# Altium schematic sheet LongHaul_Diff.SchDoc
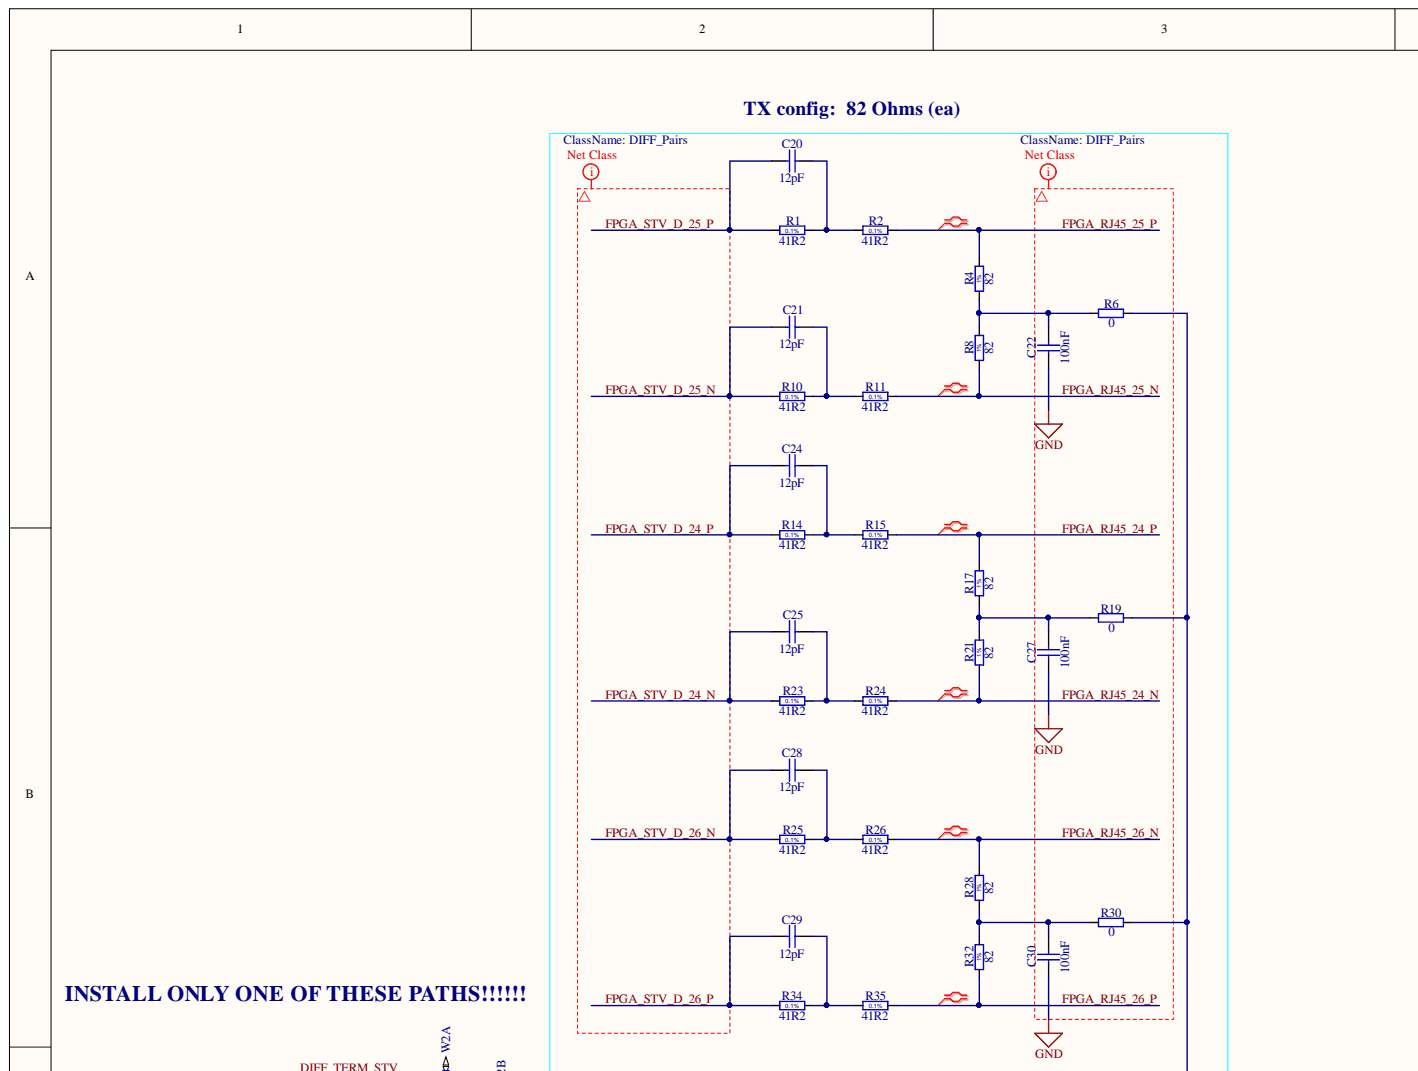
[diagram p0: LongHaul_Diff.SchDoc, top left]
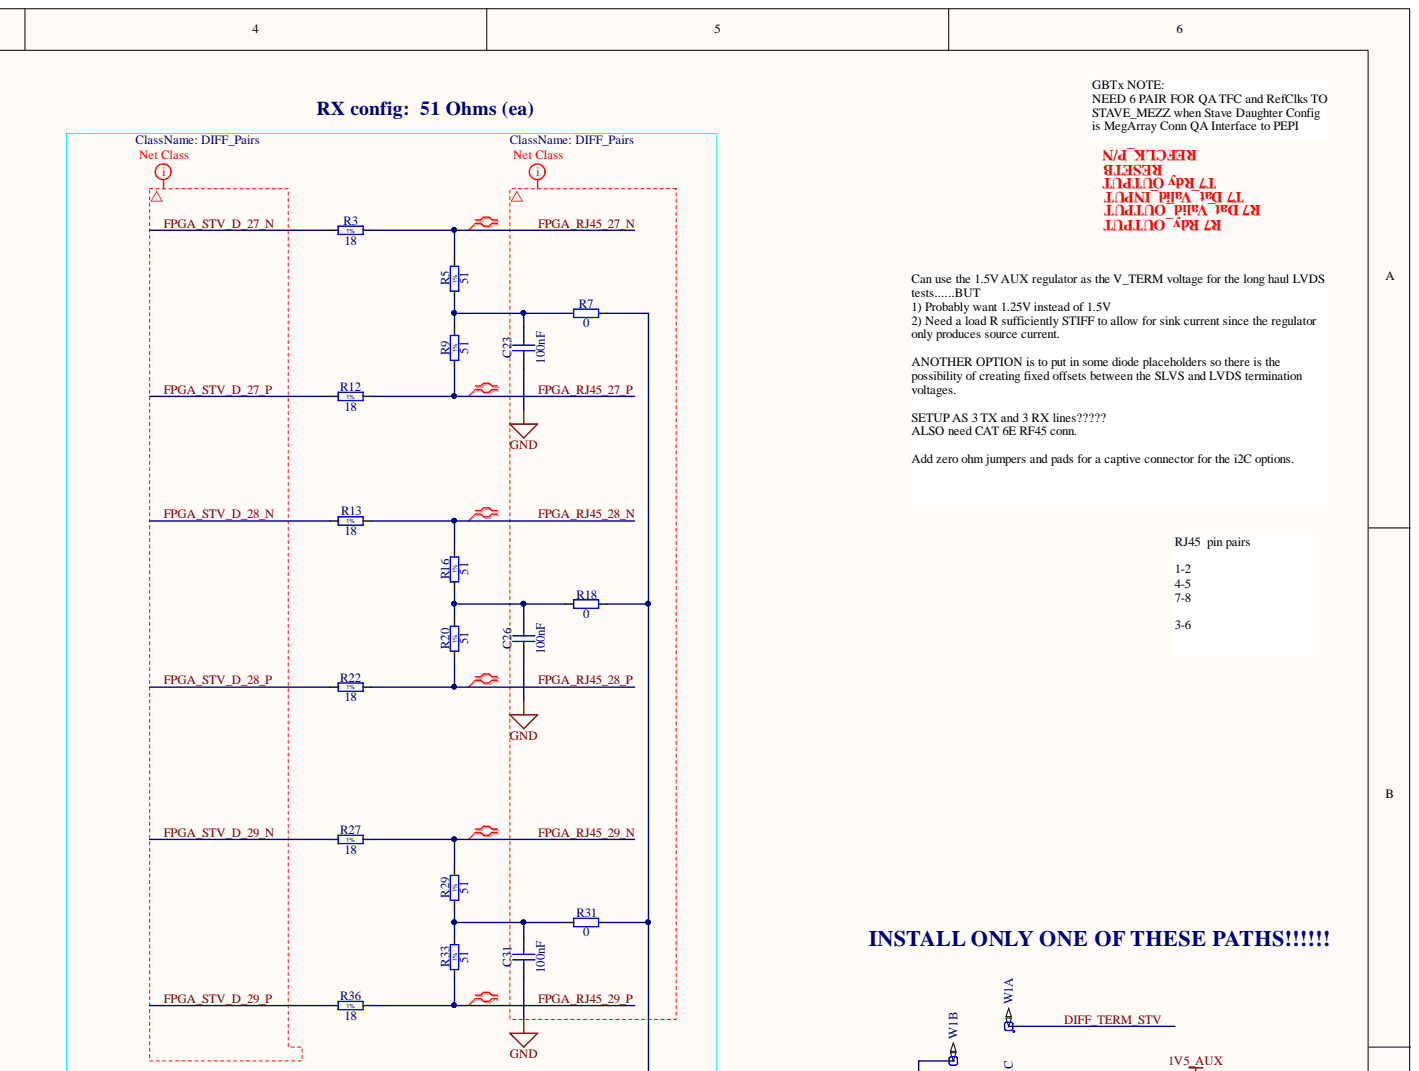
[diagram p1: LongHaul_Diff.SchDoc, top right]
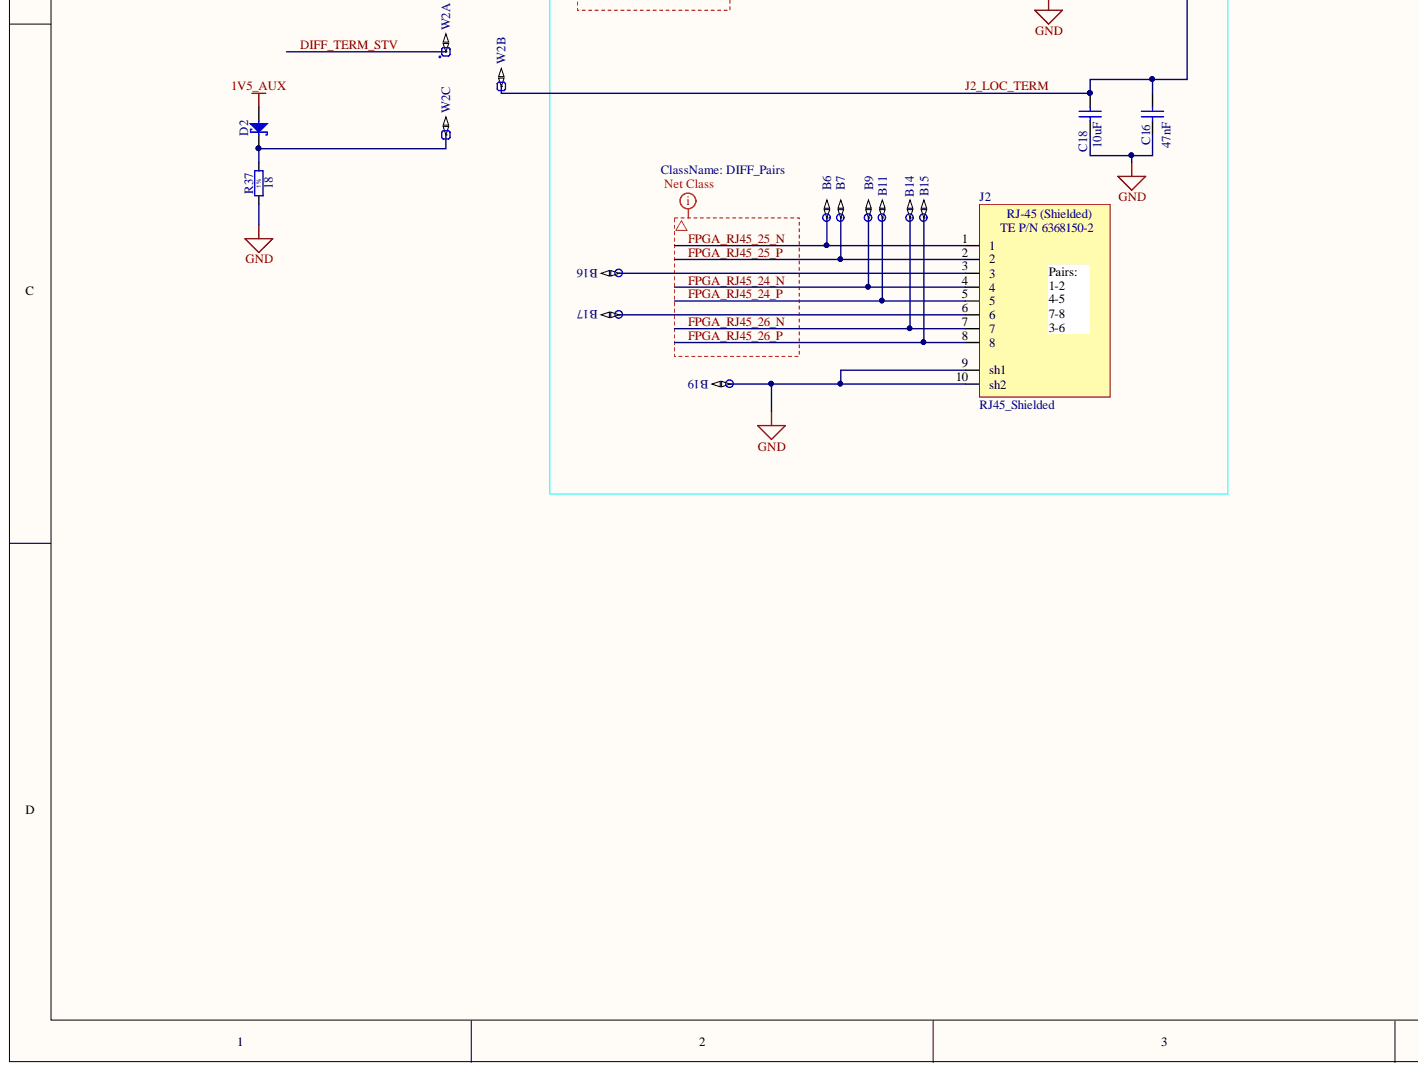
[diagram p2: LongHaul_Diff.SchDoc, bottom left]
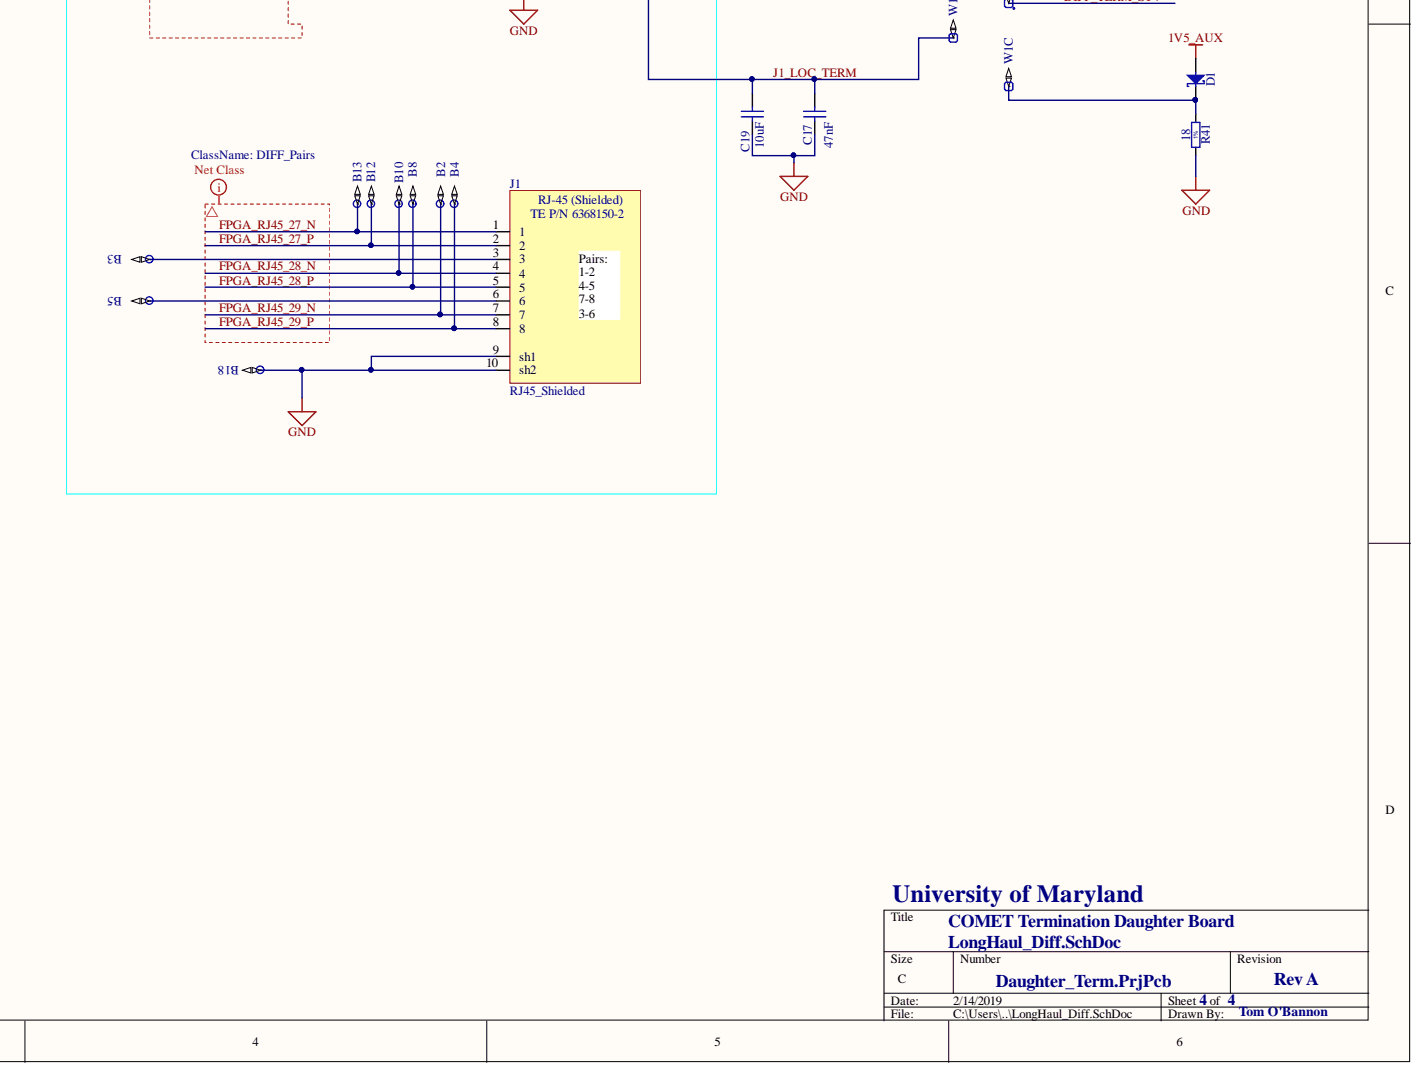
[diagram p3: LongHaul_Diff.SchDoc, bottom right]

## Parts
B2  Plated Hole  [c150h100]  (value not drawn)  (no BOM)
B3  Plated Hole  [c150h100]  (value not drawn)  (no BOM)
B4  Plated Hole  [c150h100]  (value not drawn)  (no BOM)
B5  Plated Hole  [c150h100]  (value not drawn)  (no BOM)
B6  Plated Hole  [c150h100]  (value not drawn)  (no BOM)
B7  Plated Hole  [c150h100]  (value not drawn)  (no BOM)
B8  Plated Hole  [c150h100]  (value not drawn)  (no BOM)
B9  Plated Hole  [c150h100]  (value not drawn)  (no BOM)
B10  Plated Hole  [c150h100]  (value not drawn)  (no BOM)
B11  Plated Hole  [c150h100]  (value not drawn)  (no BOM)
B12  Plated Hole  [c150h100]  (value not drawn)  (no BOM)
B13  Plated Hole  [c150h100]  (value not drawn)  (no BOM)
B14  Plated Hole  [c150h100]  (value not drawn)  (no BOM)
B15  Plated Hole  [c150h100]  (value not drawn)  (no BOM)
B16  Plated Hole  [c150h100]  (value not drawn)  (no BOM)
B17  Plated Hole  [c150h100]  (value not drawn)  (no BOM)
B18  Plated Hole  [c150h100]  (value not drawn)  (no BOM)
B19  Plated Hole  [c150h100]  (value not drawn)  (no BOM)
C16  47nF  [CAPC2012X135N]
C17  47nF  [CAPC2012X135N]
C18  10uF  [CAPC2012X135N]
C19  10uF  [CAPC2012X135N]
C20  12pF  [CAPC2012X135N]
C21  12pF  [CAPC2012X135N]
C22  100nF  [CAPC2012X135N]
C23  100nF  [CAPC2012X135N]
C24  12pF  [CAPC2012X135N]
C25  12pF  [CAPC2012X135N]
C26  100nF  [CAPC2012X135N]
C27  100nF  [CAPC2012X135N]
C28  12pF  [CAPC2012X135N]
C29  12pF  [CAPC2012X135N]
C30  100nF  [CAPC2012X135N]
C31  100nF  [CAPC2012X135N]
D1  MBR0530T1G  [SOD3715X145N]  (value not drawn)
D2  MBR0530T1G  [SOD3715X145N]  (value not drawn)
J1  RJ45_Shielded  [RJ45_Shielded]
J2  RJ45_Shielded  [RJ45_Shielded]
R1  41R2  [RESC2012X60N]
R2  41R2  [RESC2012X60N]
R3  18  [RESC2012X60N]
R4  82  [RESC2012X60N]
R5  51  [RESC2012X60N]
R6  0  [RESC2012X60N]
R7  0  [RESC2012X60N]
R8  82  [RESC2012X60N]
R9  51  [RESC2012X60N]
R10  41R2  [RESC2012X60N]
R11  41R2  [RESC2012X60N]
R12  18  [RESC2012X60N]
R13  18  [RESC2012X60N]
R14  41R2  [RESC2012X60N]
R15  41R2  [RESC2012X60N]
R16  51  [RESC2012X60N]
R17  82  [RESC2012X60N]
R18  0  [RESC2012X60N]
R19  0  [RESC2012X60N]
R20  51  [RESC2012X60N]
R21  82  [RESC2012X60N]
R22  18  [RESC2012X60N]
R23  41R2  [RESC2012X60N]
R24  41R2  [RESC2012X60N]
R25  41R2  [RESC2012X60N]
R26  41R2  [RESC2012X60N]
R27  18  [RESC2012X60N]
R28  82  [RESC2012X60N]
R29  51  [RESC2012X60N]
R30  0  [RESC2012X60N]
R31  0  [RESC2012X60N]
R32  82  [RESC2012X60N]
R33  51  [RESC2012X60N]
R34  41R2  [RESC2012X60N]
R35  41R2  [RESC2012X60N]
R36  18  [RESC2012X60N]
R37  18  [RESC2012X60N]
R41  18  [RESC2012X60N]
W1  Header 3  [SAMTEC_TSW-103-23-L-S]  (value not drawn)  (parts A,B,C)
W2  Header 3  [SAMTEC_TSW-103-23-L-S]  (value not drawn)  (parts A,B,C)

## Nets
1V5_AUX: D1.2 D2.2
GND: B18.1 B19.1 C16.1 C17.1 C18.1 C19.1 C22.1 C23.1 C26.1 C27.1 C30.1 C31.1 J1.9(sh1) J1.10(sh2) J2.9(sh1) J2.10(sh2) R37.1 R41.1
DIFF_TERM_STV: W1.1 W2.1
FPGA_RJ45_24_N: B9.1 J2.4 R21.1 R24.2
FPGA_RJ45_24_P: B11.1 J2.5 R15.2 R17.2
FPGA_RJ45_25_N: B6.1 J2.1 R8.1 R11.2
FPGA_RJ45_25_P: B7.1 J2.2 R2.2 R4.2
FPGA_RJ45_26_N: B14.1 J2.7 R26.2 R28.2
FPGA_RJ45_26_P: B15.1 J2.8 R32.1 R35.2
FPGA_RJ45_27_N: B13.1 J1.1 R3.2 R5.2
FPGA_RJ45_27_P: B12.1 J1.2 R9.1 R12.2
FPGA_RJ45_28_N: B10.1 J1.4 R13.2 R16.2
FPGA_RJ45_28_P: B8.1 J1.5 R20.1 R22.2
FPGA_RJ45_29_N: B2.1 J1.7 R27.2 R29.2
FPGA_RJ45_29_P: B4.1 J1.8 R33.1 R36.2
FPGA_STV_D_24_N: C25.1 R23.1
FPGA_STV_D_24_P: C24.1 R14.1
FPGA_STV_D_25_N: C21.1 R10.1
FPGA_STV_D_25_P: C20.1 R1.1
FPGA_STV_D_26_N: C28.1 R25.1
FPGA_STV_D_26_P: C29.1 R34.1
FPGA_STV_D_27_N: R3.1
FPGA_STV_D_27_P: R12.1
FPGA_STV_D_28_N: R13.1
FPGA_STV_D_28_P: R22.1
FPGA_STV_D_29_N: R27.1
FPGA_STV_D_29_P: R36.1
J1_LOC_TERM: C17.2 C19.2 R7.2 R18.2 R31.2 W1.2
J2_LOC_TERM: C16.2 C18.2 R6.2 R19.2 R30.2 W2.2
NetB3_1: B3.1 J1.3
NetB5_1: B5.1 J1.6
NetB16_1: B16.1 J2.3
NetB17_1: B17.1 J2.6
NetC20_2: C20.2 R1.2 R2.1
NetC21_2: C21.2 R10.2 R11.1
NetC22_2: C22.2 R4.1 R6.1 R8.2
NetC23_2: C23.2 R5.1 R7.1 R9.2
NetC24_2: C24.2 R14.2 R15.1
NetC25_2: C25.2 R23.2 R24.1
NetC26_2: C26.2 R16.1 R18.1 R20.2
NetC27_2: C27.2 R17.1 R19.1 R21.2
NetC28_2: C28.2 R25.2 R26.1
NetC29_2: C29.2 R34.2 R35.1
NetC30_2: C30.2 R28.1 R30.1 R32.2
NetC31_2: C31.2 R29.1 R31.1 R33.2
NetD1_1: D1.1 R41.2 W1.3
NetD2_1: D2.1 R37.2 W2.3
N00348:
N00349:
N00350:
N00351:
N00352:
N00353:

## Library data of the parts
B2-B19 = PLATED_HOLE1.0_PAD1.5: Single Terminal Socket; Part Description: Plated Through Hole: Hole Dia.=1.0mm Pad Dia.=1.5mm; Database Table Name: Pads; Mounted: No; ComponentHeight: 0mm; Component Type: Standard (No BOM); CreateDate: 10/30/2008; Family: Plated Hole; LatestRevisionDate: 10/30/2008; Library Name: Eletro-mechanical.DbLib; PackageDescription: Plated Through Hole: Hole Dia.=1mm Pad Dia.=1.5mm; Part Number: PLATED_HOLE1.0_PAD1.5; Pin Count: 1; SMD: No; Socket: No; Status: None; Table: Pads; Author: CERN DEM JLC
C16 C17 = CC0805_100NF_50V_10%_X7R: Non-polarised capacitor; Manufacturer: GENERIC; Manufacturer1 Part Number: B37941K5104K62; Part Description: SMD Multilayer Chip Ceramic Capacitor; Database Table Name: Capacitors SMD; TC: X7R; Tolerance: ±10%; Voltage: 10V; Case: 0805; Component Kind: Standard; Component Type: Standard; CreateDate: 12/3/2007; HelpURL: \\cern.ch\dfs\Applications\Altium\Datasheets\CC0805_X7R_EPCOS.pdf; LatestRevisionDate: 12/3/2007; Library Name: Capacitors.DbLib; Manufacturer1 ComponentHeight: 1.35mm; Manufacturer1 Example: EPCOS; Manufacturer Part Number: CC0805_100NF_50V_10%_X7R; Mounted: Yes; Part Number: CC0805_100NF_50V_10%_X7R; Pin Count: 2; SMD: Yes; Socket: No; Status: Preferred; Table: Capacitors SMD; Value: 47nF; Author: CERN DEM JLC
C18 C19 = CC0805_10UF_10V_10%_X7R: Non-polarised capacitor; Manufacturer: GENERIC; Manufacturer1 Part Number: GRM21BR71A106KE51L; Part Description: SMD Multilayer Chip Ceramic Capacitor; Database Table Name: Capacitors SMD; TC: X7R; Tolerance: ±10%; Voltage: 10V; Case: 0805; Component Kind: Standard; Component Type: Standard; CreateDate: 4/30/2012; HelpURL: \\cern.ch\dfs\Applications\Altium\Datasheets\CC0805_X7R_MURATA.pdf; LatestRevisionDate: 4/30/2012; Library Name: Capacitors.DbLib; Manufacturer1 ComponentHeight: 1.35mm; Manufacturer1 Example: MURATA; Manufacturer Part Number: CC0805_10UF_10V_10%_X7R; Mounted: Yes; Part Number: CC0805_10UF_10V_10%_X7R; Pin Count: 2; SMD: Yes; Socket: No; Status: Preferred; Table: Capacitors SMD; Value: 10uF; Author: CERN DEM JLC
C20 C21 C24 C25 C28 C29 = CC0805_12PF_50V_5%_NP0: Non-polarised capacitor; Manufacturer: GENERIC; Manufacturer1 Part Number: 223886115129; Part Description: SMD Multilayer Chip Ceramic Capacitor; Database Table Name: Capacitors SMD; TC: NP0; Tolerance: ±5%; Voltage: 50V; Case: 0805; Component Kind: Standard; Component Type: Standard; CreateDate: 12/3/2007; HelpURL: \\cern.ch\dfs\Applications\Altium\Datasheets\CC0805_NP0_PHYCOMP.pdf; LatestRevisionDate: 12/3/2007; Library Name: Capacitors.DbLib; Manufacturer1 ComponentHeight: 1.35mm; Manufacturer1 Example: PHYCOMP; Manufacturer Part Number: CC0805_12PF_50V_5%_NP0; Mounted: Yes; Part Number: CC0805_12PF_50V_5%_NP0; Pin Count: 2; SMD: Yes; Socket: No; Status: Preferred; Table: Capacitors SMD; Value: 12pF; Author: CERN DEM JLC
C22 C23 C26 C27 C30 C31 = CC0805_100NF_50V_10%_X7R: Non-polarised capacitor; Manufacturer: GENERIC; Manufacturer1 Part Number: B37941K5104K62; Part Description: SMD Multilayer Chip Ceramic Capacitor; Database Table Name: Capacitors SMD; TC: X7R; Tolerance: ±10%; Voltage: 50V; Case: 0805; Component Kind: Standard; Component Type: Standard; CreateDate: 12/3/2007; HelpURL: \\cern.ch\dfs\Applications\Altium\Datasheets\CC0805_X7R_EPCOS.pdf; LatestRevisionDate: 12/3/2007; Library Name: Capacitors.DbLib; Manufacturer1 ComponentHeight: 1.35mm; Manufacturer1 Example: EPCOS; Manufacturer Part Number: CC0805_100NF_50V_10%_X7R; Mounted: Yes; Part Number: CC0805_100NF_50V_10%_X7R; Pin Count: 2; SMD: Yes; Socket: No; Status: Preferred; Table: Capacitors SMD; Value: 100nF; Author: CERN DEM JLC
D1 D2 = MBR0530T1G: Schottky Diode; Manufacturer: ON SEMICONDUCTOR; Part Description: Schottky Power Rectifier; Database Table Name: Diodes; Power: 500mA; Voltage: 30V; Case: SOD-123; ComponentHeight: 1.45mm; Component Kind: Standard; Component Type: Standard; CreateDate: 7/21/2010; Device: MBR0530T1G; HelpURL: \\cern.ch\dfs\Applications\Altium\Datasheets\MBR0530T1G.pdf; LatestRevisionDate: 7/21/2010; Library Name: ICs And Semiconductors.DbLib; Manufacturer Part Number: MBR0530T1G; Mounted: Yes; PackageDescription: SOD Diode (SOD-123); 2.70mm W X 1.55mm L X 1.45mm H body, IPC Medium Density; Part Number: MBR0530T1G; Pin Count: 2; SMD: Yes; Socket: No; Table: Diodes; Author: CERN DEM JLC
R1 R2 R10 R11 R14 R15 R23-R26 R34 R35 = R0805_41R2_0.1%_0.1W_10PPM: Resistor - 0.1%; Manufacturer: GENERIC; Manufacturer1 Part Number: RN73C2A41R2BTG; Part Description: Thin Film Chip Resistor; Database Table Name: Resistors SMD; Power: 0.1W; TC: ±10ppm; Case: 0805; Component Kind: Standard; Component Type: Standard; CreateDate: 12/3/2007; HelpURL: \\cern.ch\dfs\Applications\Altium\Datasheets\R0805_Tyco_RN73.pdf; LatestRevisionDate: 3/13/2008; Library Name: Resistors.DbLib; Manufacturer1 ComponentHeight: 0.6mm; Manufacturer1 Example: TYCO HOLSWORTHY; Manufacturer Part Number: R0805_41R2_0.1%_0.1W_10PPM; Mounted: Yes; Part Number: R0805_41R2_0.1%_0.1W_10PPM; Pin Count: 2; SMD: Yes; Socket: No; Status: Not recommended; Table: Resistors SMD; Tolerance: ±0.1%; Value: 41R2; Author: CERN DEM JLC
R3 R12 R13 R22 R27 R36 R37 R41 = R0805_18R_1%_0.125W_100PPM: Resistor - 1%; Manufacturer: GENERIC; Manufacturer1 Part Number: NRC10F18R0TRF; Part Description: General Purpose Thick Film Chip Resistor; Database Table Name: Resistors SMD; Power: 0.125W; TC: ±100ppm/°C; Case: 0805; Component Kind: Standard; Component Type: Standard; CreateDate: 12/3/2007; HelpURL: \\cern.ch\dfs\Applications\Altium\Datasheets\R0805_NIC_NRC.pdf; LatestRevisionDate: 3/13/2008; Library Name: Resistors.DbLib; Manufacturer1 ComponentHeight: 0.6mm; Manufacturer1 Example: NIC COMPONENT; Manufacturer Part Number: R0805_18R_1%_0.125W_100PPM; Mounted: Yes; Part Number: R0805_18R_1%_0.125W_100PPM; Pin Count: 2; SMD: Yes; Socket: No; Status: Preferred; Table: Resistors SMD; Tolerance: ±1%; Value: 18; Author: CERN DEM JLC
R4 R8 R17 R21 R28 R32 = R0805_82R_1%_0.125W_100PPM: Resistor - 1%; Manufacturer: GENERIC; Manufacturer1 Part Number: NRC10F82R0TRF; Part Description: General Purpose Thick Film Chip Resistor; Database Table Name: Resistors SMD; Power: 0.125W; TC: ±100ppm/°C; Case: 0805; Component Kind: Standard; Component Type: Standard; CreateDate: 12/3/2007; HelpURL: \\cern.ch\dfs\Applications\Altium\Datasheets\R0805_NIC_NRC.pdf; LatestRevisionDate: 3/13/2008; Library Name: Resistors.DbLib; Manufacturer1 ComponentHeight: 0.6mm; Manufacturer1 Example: NIC COMPONENT; Manufacturer Part Number: R0805_82R_1%_0.125W_100PPM; Mounted: Yes; Part Number: R0805_82R_1%_0.125W_100PPM; Pin Count: 2; SMD: Yes; Socket: No; Status: Preferred; Table: Resistors SMD; Tolerance: ±1%; Value: 82; Author: CERN DEM JLC
R5 R9 R16 R20 R29 R33 = R0805_51R_1%_0.125W_100PPM: Resistor - 1%; Manufacturer: GENERIC; Manufacturer1 Part Number: NRC10F51R0TRF; Part Description: General Purpose Thick Film Chip Resistor; Database Table Name: Resistors SMD; Power: 0.125W; TC: ±100ppm/°C; Case: 0805; Component Kind: Standard; Component Type: Standard; CreateDate: 12/3/2007; HelpURL: \\cern.ch\dfs\Applications\Altium\Datasheets\R0805_NIC_NRC.pdf; LatestRevisionDate: 3/13/2008; Library Name: Resistors.DbLib; Manufacturer1 ComponentHeight: 0.6mm; Manufacturer1 Example: NIC COMPONENT; Manufacturer Part Number: R0805_51R_1%_0.125W_100PPM; Mounted: Yes; Part Number: R0805_51R_1%_0.125W_100PPM; Pin Count: 2; SMD: Yes; Socket: No; Status: Preferred; Table: Resistors SMD; Tolerance: ±1%; Value: 51; Author: CERN DEM JLC
R6 R7 R18 R19 R30 R31 = R0805_0R_JUMPER: Resistor; Manufacturer: GENERIC; Manufacturer1 Part Number: NRC10Z0; Part Description: 2A (0.05R Max DC Resistance) Zero Ohm Jumper; Database Table Name: Resistors SMD; Case: 0805; Component Kind: Standard; Component Type: Standard; CreateDate: 12/3/2007; HelpURL: \\cern.ch\dfs\Applications\Altium\Datasheets\R0805_NIC_NRC.pdf; LatestRevisionDate: 3/13/2008; Library Name: Resistors.DbLib; Manufacturer1 ComponentHeight: 0.6mm; Manufacturer1 Example: NIC COMPONENT; Manufacturer Part Number: R0805_0R_JUMPER; Mounted: Yes; Part Number: R0805_0R_JUMPER; Pin Count: 2; SMD: Yes; Socket: No; Status: Preferred; Table: Resistors SMD; Value: 0; Author: CERN DEM JLC
W1 W2 = SAMTEC_TSW-103-23-L-S: 3 Mini Wire-Wrap Contact Strip Header; Part Description: 3 Contacts - Pitch 2.54mm - Single Row  Through Mount Straigh Header (Post Lead 5.84mm, Tail Lead 2.92mm, Insulator 2.54mm); Database Table Name: SAMTEC; ComponentHeight: 8.38mm; Component Kind: Standard; Component Type: Standard; CreateDate: 10/10/2012; Family: Header 3; HelpURL: \\cern.ch\dfs\Applications\Altium\Datasheets\SAMTEC_TSW-103-23-L-S.pdf; LatestRevisionDate: 10/10/2012; Library Name: Connectors.DbLib; Manufacturer: SAMTEC; Manufacturer Part Number: TSW-103-23-L-S; Mounted: Yes; Part Number: SAMTEC_TSW-103-23-L-S; Pin Count: 3; SMD: No; Socket: No; Status: None; Table: SAMTEC; Author: CERN DEM JLC
J1 J2 = RJ45_Shielded: Component Kind: Standard; Library Name: Misc_Conns_Integr_Lib.IntLib
